# Altium schematic sheet RF_Pi.SchDoc(RF_Pi)
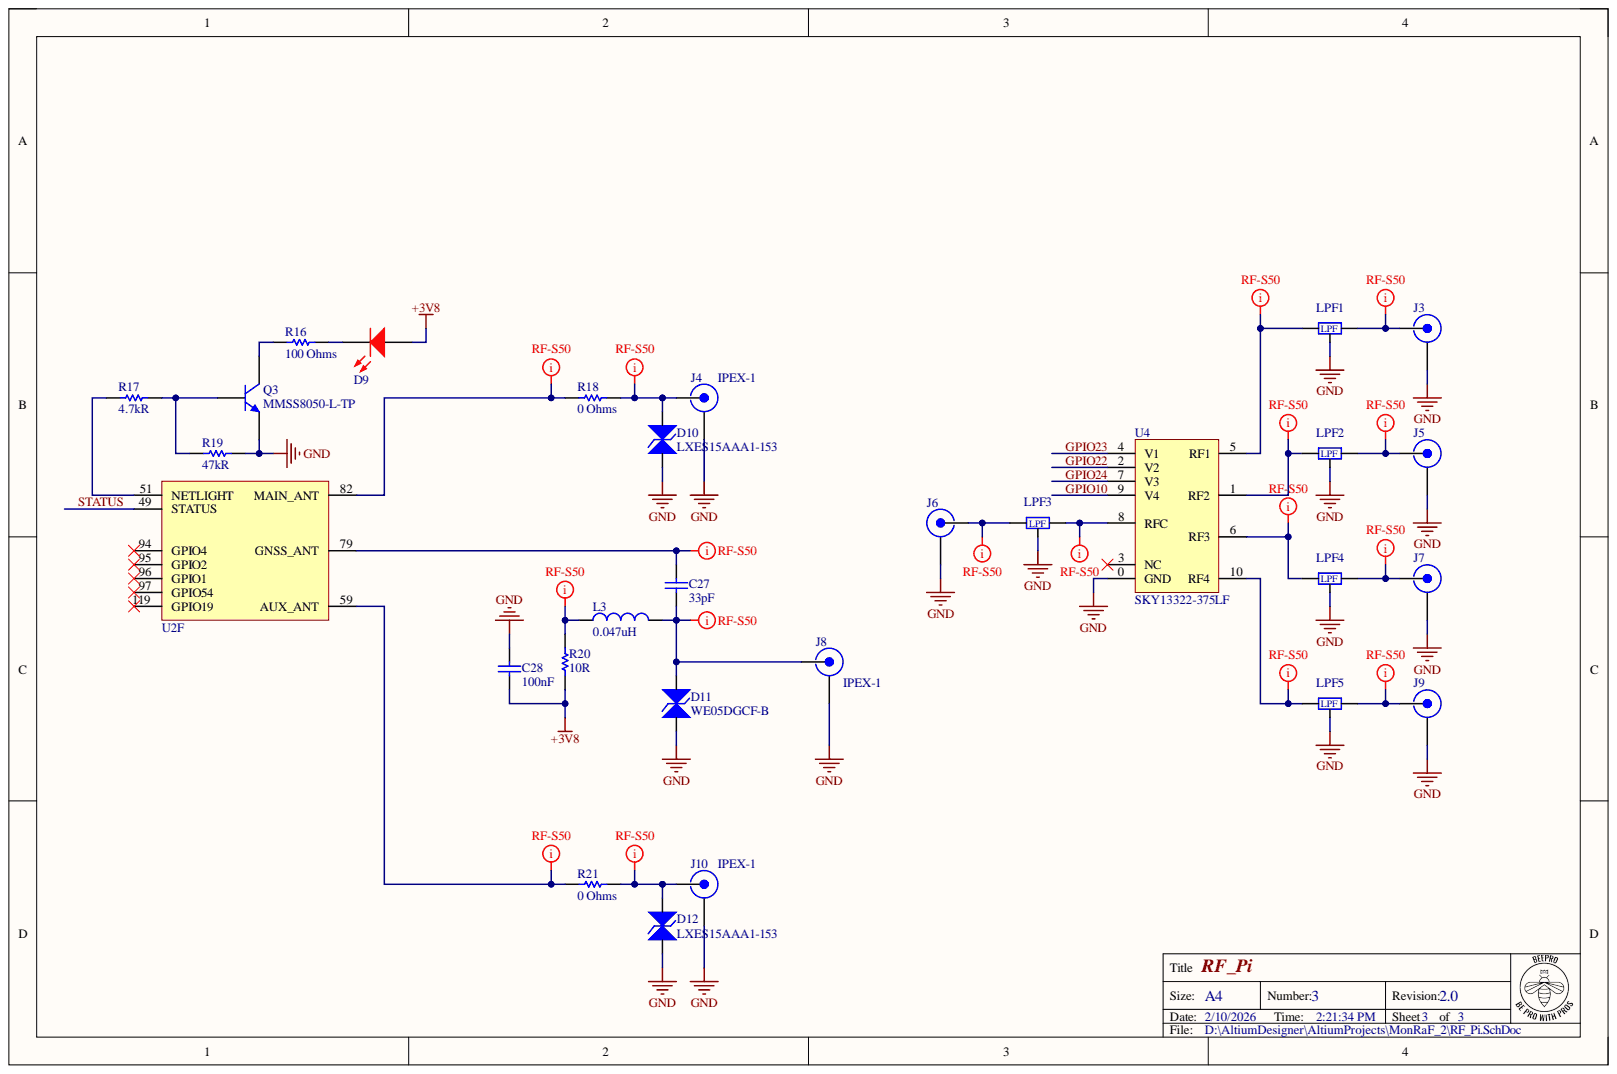
[diagram p0: RF_Pi.SchDoc]

## Parts
C27  value 33pF  [0402N]  (hidden comment: CC0402JRNPO9BN330)
C28  Capacitance: 100nF  [0402N]  (hidden comment: 04026D104KAT2A)
D9  150060RS75000  [0603_LED_RED]  (value not drawn)
D10  LXES15AAA1-153  [DFN90P100X45-2N]
D11  WE05DGCF-B  [DFN1006-2N]
D12  LXES15AAA1-153  [DFN90P100X45-2N]
J3  BWSMA-KE-P001  [SMA]  (value not drawn)
J4  IPEX-1  [IPEX-1]
J5  BWSMA-KE-P001  [SMA]  (value not drawn)
J6  BWSMA-KE-P001  [SMA]  (value not drawn)
J7  BWSMA-KE-P001  [SMA]  (value not drawn)
J8  IPEX-1  [IPEX-1]
J9  BWSMA-KE-P001  [SMA]  (value not drawn)
J10  IPEX-1  [IPEX-1]
L3  Inductance-Nom (L): 0.047uH  [0402N]  (hidden comment: LQG15HS47NJ02D)
LPF1  LFCN-6000+  [LPF3216X09N]  (value not drawn)
LPF2  LFCN-6000+  [LPF3216X09N]  (value not drawn)
LPF3  LFCN-6000+  [LPF3216X09N]  (value not drawn)
LPF4  LFCN-6000+  [LPF3216X09N]  (value not drawn)
LPF5  LFCN-6000+  [LPF3216X09N]  (value not drawn)
Q3  MMSS8050-L-TP  [SOT23-3N]
R16  Resistance: 100 Ohms  [0402N]  (hidden comment: CRCW0402100RJNED)
R17  Resistance: 4.7kR  [0402N]  (hidden comment: RC0402FR-074K7L)
R18  Resistance: 0 Ohms  [0402N]  (hidden comment: CRCW04020000Z0ED)
R19  Resistance: 47kR  [0402N]  (hidden comment: AC0402FR-0747KL)
R20  Resistance: 10R  [0402N]  (hidden comment: ERJ-U02F10R0X)
R21  Resistance: 0 Ohms  [0402N]  (hidden comment: CRCW04020000Z0ED)
U2  SIM7600G-H  [LCC+LGA-119N]  (value not drawn)  (part F)
U4  SKY13322-375LF  [MLPD-11N]

## Nets
+3V8: C28.2 D9.2 R20.2
GND: C28.1 D10.1 D11.1 D12.1 J3.2 J4.2 J5.2 J6.2 J7.2 J8.2 J9.2 J10.2 LPF1.2 LPF2.2 LPF3.2 LPF4.2 LPF5.2 Q3.2 R19.2 U4.0(GND)
GPIO10: U4.9(V4)
GPIO22: U4.2(V2)
GPIO23: U4.4(V1)
GPIO24: U4.7(V3)
STATUS: U2.49(STATUS)
NetC27_1: C27.1 D11.2 J8.1 L3.2
NetC27_2: C27.2 U2.79(GNSS_ANT)
NetD9_1: D9.1 R16.2
NetD10_2: D10.2 J4.1 R18.2
NetD12_2: D12.2 J10.1 R21.2
NetJ3_1: J3.1 LPF1.1
NetJ5_1: J5.1 LPF2.1
NetJ6_1: J6.1 LPF3.3
NetJ7_1: J7.1 LPF4.1
NetJ9_1: J9.1 LPF5.1
NetL3_1: L3.1 R20.1
NetLPF1_3: LPF1.3 U4.5(RF1)
NetLPF2_3: LPF2.3 U4.1(RF2)
NetLPF3_1: LPF3.1 U4.8(RFC)
NetLPF4_3: LPF4.3 U4.6(RF3)
NetLPF5_3: LPF5.3 U4.10(RF4)
NetQ3_1: Q3.1 R17.2 R19.1
NetQ3_3: Q3.3 R16.1
NetR17_1: R17.1 U2.51(NETLIGHT)
NetR18_1: R18.1 U2.82(MAIN_ANT)
NetR21_1: R21.1 U2.59(AUX_ANT)

Not connected: U2 94(GPIO4) 95(GPIO2) 96(GPIO1) 97(GPIO54) 119(GPIO19); U4 3(NC)

## Library data of the parts
C27 = CC0402JRNPO9BN330: Ceramic Capacitor, Multilayer, Ceramic, 50V, 5% +Tol, 5% -Tol, C0G, 30ppm/Cel TC, 0.000033uF, Surface Mount, 0402; Manufacturer: Yageo; Multilayer: Yes; Capacitor Type: Ceramic; Case/Package: 0402; Category: Ceramic Capacitors; Component Kind: Standard; ComponentLink1Description: https://datasheet.ciiva.com:443/pdfs/VipMasterIC/IC/YAGO/YAGO-S-A0009251638/YAGO-S-A0009251638-1.pdf?src-supplier=IHS+Markit; ComponentLink1URL: https://datasheet.ciiva.com:443/pdfs/VipMasterIC/IC/YAGO/YAGO-S-A0009251638/YAGO-S-A0009251638-1.pdf?src-supplier=IHS+Markit; ComponentLink2Description: https://datasheet.ciiva.com/143/mlccorderingcode-143995.pdf?src-supplier=Digikey; ComponentLink2URL: https://datasheet.ciiva.com/143/mlccorderingcode-143995.pdf?src-supplier=Digikey; ComponentLink3Description: https://datasheet.ciiva.com/143/mlccorderingcode-143996.pdf?src-supplier=Digikey; ComponentLink3URL: https://datasheet.ciiva.com/143/mlccorderingcode-143996.pdf?src-supplier=Digikey; ComponentLink4Description: https://datasheet.ciiva.com/143/mlccorderingcode-143997.pdf?src-supplier=Digikey; ComponentLink4URL: https://datasheet.ciiva.com/143/mlccorderingcode-143997.pdf?src-supplier=Digikey; Dielectric Material: Ceramic; Height: 0.5mm; JESD-609 Code: e3; Length: 1mm; Library Name: Capacitor_Ceramic.IntLib; Manufacturer Part Number: CC0402JRNPO9BN330; Max Operating Temperature: 125°C; Min Operating Temperature: -55°C; Mounting Technology: Surface Mount; Package Shape: Rectangular; Package Style: SMTMeter; Packaging: Tape and Reel; Pins: 2; Pricing: 1=0.1, 10=0.016, 50=0.016, 100=0.01, 1000=0.008, 10000=0.005 (USD); Rated DC Voltage (URdc): 50V; Reach SVHC Compliant: Yes; RoHS: Yes; RoHS Compliant: Yes; Stock: 175157; Supplier: Mouser; Supplier Part Number: 603-CC402JRNPO9BN330; Temperature Characteristics Code: C0G; Terminal Finish: Nickel; Termination: Wraparound; Tolerance  (fill in as +-): 5%; Tolerance (fill in as +-): 5%; Width: 0.5mm
C28 = 04026D104KAT2A: AVX   04026D104KAT2A   Multilayer Ceramic Capacitor, 0.1 F,  10%, X5R, 6.3 V, 0402 [1005 Metric]; Manufacturer: Kyocera AVX; Voltage: 6.3V; Case Code (Imperial): 0402; Case Code (Metric): 1005; Case/Package: 0402; Component Kind: Standard; ComponentLink1Description: https://datasheet.ciiva.com/11787/0900766b80def1a5-11787108.pdf?src-supplier=RSComponents; ComponentLink1URL: https://datasheet.ciiva.com/11787/0900766b80def1a5-11787108.pdf?src-supplier=RSComponents; ComponentLink2Description: https://datasheet.ciiva.com/34303/2009885-34303800.pdf?src-supplier=Jameco; ComponentLink2URL: https://datasheet.ciiva.com/34303/2009885-34303800.pdf?src-supplier=Jameco; ComponentLink3Description: https://datasheet.ciiva.com/1123/1666675-1123895.pdf?src-supplier=Element14; ComponentLink3URL: https://datasheet.ciiva.com/1123/1666675-1123895.pdf?src-supplier=Element14; ComponentLink4Description: https://datasheet.ciiva.com/7709/1666675-7709559.pdf?src-supplier=Element14; ComponentLink4URL: https://datasheet.ciiva.com/7709/1666675-7709559.pdf?src-supplier=Element14; ComponentLink5Description: https://datasheet.ciiva.com/17488/cx5r-17488599.pdf?src-supplier=Avnet+Europe; ComponentLink5URL: https://datasheet.ciiva.com/17488/cx5r-17488599.pdf?src-supplier=Avnet+Europe; ComponentLink6Description: https://datasheet.ciiva.com/1123/1666675-1123896.pdf?src-supplier=Element14; ComponentLink6URL: https://datasheet.ciiva.com/1123/1666675-1123896.pdf?src-supplier=Element14; ComponentLink7Description: https://datasheet.ciiva.com/11499/cx5r-11499578.pdf?src-supplier=Avnet; ComponentLink7URL: https://datasheet.ciiva.com/11499/cx5r-11499578.pdf?src-supplier=Avnet; ComponentLink8Description: https://datasheet.ciiva.com/210/cx5r-210347.pdf?src-supplier=Digi-Key; ComponentLink8URL: https://datasheet.ciiva.com/210/cx5r-210347.pdf?src-supplier=Digi-Key; ComponentLink9Description: https://datasheet.ciiva.com/14886/cx5r-14886812.pdf?src-supplier=Digi-Key; ComponentLink9URL: https://datasheet.ciiva.com/14886/cx5r-14886812.pdf?src-supplier=Digi-Key; ComponentLink10Description: https://datasheet.ciiva.com/2987/cx5r-2987693.pdf?src-supplier=Avnet; ComponentLink10URL: https://datasheet.ciiva.com/2987/cx5r-2987693.pdf?src-supplier=Avnet; Contact Plating: Tin; Depth: 0.5mm; Dielectric: X5R; Dielectric Material: Ceramic; Height: 0.56mm; Lead Free: Lead Free; Length: 1mm; Library Name: Capacitor_Ceramic.IntLib; Manufacturer Part Number: 04026D104KAT2A; Max Operating Temperature: 85°C; Min Operating Temperature: -55°C; Mount: Surface Mount; Package Quantity: 1; Packaging: Tape and Reel; Pricing 1: 1 = 0.10000, 10 = 0.04100, 50 = 0.04100, 100 = 0.01900, 1000 = 0.01000, 10000 = 0.00700 (USD); Radiation Hardening: No; REACH SVHC: No SVHC; RoHS: Yes; Stock 1: 118561; Supplier 1: Mouser; Supplier Part Number 1: 581-04026D104KAT2A; Termination: SMD/SMT; Thickness: 0.022inch; Tolerance: 10%; Voltage Rating: 6.3V; Voltage Rating (DC): 6.3V; Width: 0.02inch
D9 = 150060RS75000: WURTH ELEKTRONIK - 150060RS75000 - LED, 0603, RED, 250MCD, 625NM; Manufacturer: Wurth Electronics; Forward Current: 30mA; Forward Voltage: 2V; Lens Style: Clear,Rectangular; Test Current: 20mA; Viewing Angle: 140°; Case Code (Imperial): 0603; Case Code (Metric): 1608; Case/Package: 0603; Color: Red; Component Kind: Standard; ComponentLink1Description: https://katalog.we-online.de/led/datasheet/150060RS75000.pdf?src-supplier=Digi-Key; ComponentLink1URL: https://katalog.we-online.de/led/datasheet/150060RS75000.pdf?src-supplier=Digi-Key; ComponentLink2Description: http://www.produktinfo.conrad.com/datenblaetter/750000-774999/759428-da-01-en-CHIPLED_0603_W_KL_ROT_150060RS75000.pdf?src-supplier=Conrad; ComponentLink2URL: http://www.produktinfo.conrad.com/datenblaetter/750000-774999/759428-da-01-en-CHIPLED_0603_W_KL_ROT_150060RS75000.pdf?src-supplier=Conrad; Dominant Wavelength: 625nm; Height: 0.7mm; Length: 1.6mm; Library Name: Diodes.IntLib; Luminous Intensity: 250mcd; Manufacturer Part Number: 150060RS75000; Max Operating Temperature: 85°C; Min Operating Temperature: -40°C; Mount: Surface Mount; Package Quantity: 1; Packaging: Cut Tape; Pricing 1: 1 = 0.14000, 50 = 0.12900, 100 = 0.11000, 500 = 0.09500 (USD); REACH SVHC: Unknown; RoHS: Yes; Stock 1: 248052; Supplier 1: Digi-Key; Supplier Part Number 1: 732-4978-1-ND; Wavelength: 625nm; Width: 0.8mm
D10 D12 = LXES15AAA1-153: 0402 ESD and Surge Protection (TVS/ESD) ROHS; Manufacturer: LEIDITECH; Component Kind: Standard; Library Name: Diodes.IntLib; Manufacturer Part Number: LXES15AAA1-153; Pricing 1: 10=0.0353, 100=0.0289, 300=0.0257, 1000=0.0233, 5000=0.0204, 10000=0.0194 (USD); RoHS: No; Stock 1: 16704; Supplier 1: LCSC; Supplier Part Number 1: C5210978
D11 = WE05DGCF-B: DFN1006-2L ESD and Surge Protection (TVS/ESD) ROHS; Component Kind: Standard; Library Name: Diodes.IntLib
J3 J5-J7 J9 = BWSMA-KE-P001: 1 Inner Hole SMA Board-End Staggered pins 50Ω 5.2mm Plugin Coaxial Connectors (RF) ROHS; Manufacturer: BAT Wireless; Component Kind: Standard; Library Name: Connectors.IntLib; Manufacturer Part Number: BWSMA-KE-P001; Pricing 1: 1=0.3529, 10=0.2804, 30=0.2442, 100=0.2127, 500=0.2048, 1000=0.2001 (USD); RoHS: No; Stock 1: 44190; Supplier 1: LCSC; Supplier Part Number 1: C496550
J4 J8 J10 = IPEX-1: SMD RF Connectors / Coaxial Connectors ROHS; Manufacturer: Ziisor; Category: Uncategorized; Component Kind: Standard; Library Name: Connectors.IntLib; Manufacturer Part Number: IPEX-1; RoHS: No; Supplier 1: LCSC; Supplier Part Number 1: C2987682
L3 = LQG15HS47NJ02D: General Purpose Inductor, 0.047uH, 5%, 1 Element, Air-Core, SMD, 0402; Manufacturer: Murata; Case/Size Code: 0402; Channels: 1; Core Material: Air; DC Resistance (DCR): 720mR; Inductor Application: RF INDUCTOR; Max Current Rating: 300mA; Package Height: 0.5mm; Package Length: 1mm; Quality Factor-Min (at L-nom): 8; Self Resonant Frequency: 1GHz; Shape/Size Description: RECTANGULAR PACKAGE; Shielding: Unshielded; Test Frequency: 100MHz; Category: Fixed Inductors; Component Kind: Standard; ComponentLink1Description: https://datasheet.ciiva.com:443/pdfs/VipMasterIC/IC/MURE/MURE-S-A0004224658/MURE-S-A0004277638-1.pdf?src-supplier=IHS+Markit; ComponentLink1URL: https://datasheet.ciiva.com:443/pdfs/VipMasterIC/IC/MURE/MURE-S-A0004224658/MURE-S-A0004277638-1.pdf?src-supplier=IHS+Markit; ComponentLink2Description: https://datasheet.ciiva.com/11799/0900766b8090d685-11799071.pdf?src-supplier=Rs; ComponentLink2URL: https://datasheet.ciiva.com/11799/0900766b8090d685-11799071.pdf?src-supplier=Rs; ComponentLink3Description: https://datasheet.ciiva.com/1038/l0075s0083lqg15hs-1038339.pdf?src-supplier=Digikey; ComponentLink3URL: https://datasheet.ciiva.com/1038/l0075s0083lqg15hs-1038339.pdf?src-supplier=Digikey; ComponentLink4Description: https://datasheet.ciiva.com/1038/l0075s0083lqg15hs-1038337.pdf?src-supplier=Digikey; ComponentLink4URL: https://datasheet.ciiva.com/1038/l0075s0083lqg15hs-1038337.pdf?src-supplier=Digikey; ComponentLink5Description: https://datasheet.ciiva.com/1038/l0075s0083lqg15hs-1038338.pdf?src-supplier=Digikey; ComponentLink5URL: https://datasheet.ciiva.com/1038/l0075s0083lqg15hs-1038338.pdf?src-supplier=Digikey; ComponentLink6Description: https://datasheet.ciiva.com/4977/o05e-4977919.pdf?src-supplier=Mouser; ComponentLink6URL: https://datasheet.ciiva.com/4977/o05e-4977919.pdf?src-supplier=Mouser; ComponentLink7Description: https://datasheet.ciiva.com/18088/getdatasheetpartid-55809-18088839.pdf?src-supplier=Verical; ComponentLink7URL: https://datasheet.ciiva.com/18088/getdatasheetpartid-55809-18088839.pdf?src-supplier=Verical; Construction: Multilayer Chip; Inductor Type: GENERAL PURPOSE INDUCTOR; JESD-609 Code: e3; Library Name: Inductors.IntLib; Manufacturer Part Number: LQG15HS47NJ02D; Max Operating Temperature: 125°C; Min Operating Temperature: -55°C; Package Style: SMTMeter; Package Width: 0.5mm; Packaging: Tape and Reel; Pins: 2; Pricing 1: 1=0.1, 10=0.04, 50=0.04, 100=0.031, 1000=0.03, 10000=0.026 (USD); Reach SVHC Compliant: Yes; RoHS: Yes; RoHS Compliant: Yes; Stock 1: 11986; Supplier 1: Mouser; Supplier Part Number 1: 81-LQG15HS47NJ02D; Surface Mount: Yes; Terminal Finish: Nickel; Terminal Placement: Dual Ended; Termination: Wraparound; Tolerance: 5%
LPF1-LPF5 = LFCN-6000+: Low Pass Filter / Ceramic Filters Low Pass 6000MHz 1.2dB 50Ohm SMD 4Pin T/R; Manufacturer: Mini-Circuits; Attenuation Value: 20dB; Cutoff Frequency: 6.8GHz; Insertion Loss (dB): 3dB; Category: Ceramic Filters; Component Kind: Standard; ComponentLink1Description: https://datasheet.octopart.com:443/LFCN-6000%2B-Mini-Circuits-datasheet-180701403.pdf?src-supplier=IHS; ComponentLink1URL: https://datasheet.octopart.com:443/LFCN-6000%2B-Mini-Circuits-datasheet-180701403.pdf?src-supplier=IHS; ComponentLink2Description: https://datasheet.octopart.com:443/LFCN-6000%2B-Mini-Circuits-datasheet-103441202.pdf?src-supplier=Component+Distributors+Inc.; ComponentLink2URL: https://datasheet.octopart.com:443/LFCN-6000%2B-Mini-Circuits-datasheet-103441202.pdf?src-supplier=Component+Distributors+Inc.; Height: 940µm; Length: 3.2mm; Library Name: Inductors.IntLib; Lifecycle Status: Production; Manufacturer Part Number: LFCN-6000+; Max Operating Temperature: 100°C; Min Operating Temperature: -55°C; Pricing: 1=20.0123, 1=21.8764, 1=2.3851, 1=2.7414, 10=18.37, 10=2.0028, 10=16.8046, 10=2.302, 30=14.7855, 30=16.1627, 30=2.0254, 30=1.7621, 100=13.9188, 100=1.7442, 100=1.5175, 100=12.7327, 500=11.8012, 500=1.6166, 500=12.9005, 500=1.4065, 1000=11.3975, 1000=12.4592, 1000=1.5613, 1000=1.3584 (CNY); REACH SVHC: Yes; RoHS: Compliant; Stock: 11; Supplier: LCSC; Supplier Part Number: C879870; Width: 1.6mm
Q3 = MMSS8050-L-TP: TRANS NPN 25V 1.5A SOT-23; Manufacturer: MCC; Collector Base Voltage (VCBO): 40V; Collector Emitter Saturation Voltage: 500mV; Element Configuration: Single; Emitter Base Voltage (VEBO): 5V; Gain Bandwidth Product: 100MHz; hFE Min: 40; Max Power Dissipation: 625mW; Case/Package: TO-236-3; Collector Emitter Breakdown Voltage: 25V; Collector Emitter Voltage (VCEO): 500mV; Component Kind: Standard; ComponentLink1Description: https://datasheet.ciiva.com/6362/mmss8050sot-23-6362361.pdf; ComponentLink1URL: https://datasheet.ciiva.com/6362/mmss8050sot-23-6362361.pdf; ComponentLink2Description: https://datasheet.ciiva.com/16285/mmss8050sot-23-276387-16285577.pdf; ComponentLink2URL: https://datasheet.ciiva.com/16285/mmss8050sot-23-276387-16285577.pdf; ComponentLink3Description: https://datasheet.ciiva.com/6362/mmss8050sot-23-6362362.pdf; ComponentLink3URL: https://datasheet.ciiva.com/6362/mmss8050sot-23-6362362.pdf; ComponentLink4Description: https://datasheet.ciiva.com/6362/mmss8050sot-23-6362363.pdf; ComponentLink4URL: https://datasheet.ciiva.com/6362/mmss8050sot-23-6362363.pdf; Lead Free: Lead Free; Manufacturer Part Number: MMSS8050-L-TP; Max Breakdown Voltage: 25V; Max Collector Current: 1.5A; Max Operating Temperature: 150°C; Min Operating Temperature: -55°C; Mount: Surface Mount; Package Quantity: 3000; Packaging: Tape and Reel; Polarity: NPN; Pricing 1: 1=0.19, 10=0.165, 50=0.165, 100=0.058, 1000=0.04, 10000=0.026 (USD); Stock 1: 30112; Supplier 1: Mouser; Supplier Part Number 1: 833-MMSS8050-L-TP; Transition Frequency: 100MHz; Library Name: Micro Comercial Components.IntLib
R16 = CRCW0402100RJNED: Thick Film Resistors - SMD 1/16watt 100ohms 5%; Brand: Vishay; Power Rating: 63 mW; Qualification: Commercial; Temperature Coefficient: 200 PPM / K; Voltage Rating: 50 V; Case Code - in: 0402; Case Code - mm: 1005; Category: Thick Film Resistors - SMD; Component Kind: Standard; ComponentLink1Description: http://www.mouser.com/ds/2/427/dcrcwe3-109170.pdf; ComponentLink1URL: http://www.mouser.com/ds/2/427/dcrcwe3-109170.pdf; Features: Metal glaze on high quality ceramic; Height: 0.35 mm; Length: 1 mm; Library Name: Resistor_0402.IntLib; Manufacturer: Vishay; Manufacturer Part Number 1: CRCW0402100RJNED; Minimum Order Quantity: 1; Operating Temperature Range: - 55 C to + 155 C; Package / Case: 0402 (1005 metric); Packaging: Reel; Pricing 1: 1=0.100, 10=0.040, 100=0.023, 1000=0.016, 10000=0.004, 50000=0.003 (USD); Product: Thick Film Resistors SMD; Reeling: True; RoHS: RoHS Compliant By Exemption; RoHS - Mouser: E; Series: CRCW e3; Standard Pack Qty: 10000; Stock 1: 46909; Supplier 1: Mouser; Supplier Part Number 1: 71-CRCW0402J-100-E3; Termination Style: SMD/SMT; Tolerance: 5 %; Width: 0.5 mm
R17 = RC0402FR-074K7L: YAGEO (PHYCOMP)         RC0402FR-074K7L             SMD Chip Resistor, Thick Film, 4.7 kohm, 50 V, 0402 [1005 Metric], 63 mW,  1%, RC Series; Power Rating: 62.5mW; Temperature Coefficient: 100ppm/°C; Voltage Rating: 50V; Case Code (Imperial): 0402; Case Code (Metric): 1005; Case/Package: 0402; Component Kind: Standard; ComponentLink1Description: https://datasheet.ciiva.com/11819/0900766b80c66297-11819507.pdf; ComponentLink1URL: https://datasheet.ciiva.com/11819/0900766b80c66297-11819507.pdf; ComponentLink2Description: https://datasheet.ciiva.com/7850/1018594-7850022.pdf; ComponentLink2URL: https://datasheet.ciiva.com/7850/1018594-7850022.pdf; ComponentLink3Description: https://datasheet.ciiva.com/7850/1018594-7850023.pdf; ComponentLink3URL: https://datasheet.ciiva.com/7850/1018594-7850023.pdf; ComponentLink4Description: https://datasheet.ciiva.com/15215/getdatasheetpartid-328119-15215035.pdf; ComponentLink4URL: https://datasheet.ciiva.com/15215/getdatasheetpartid-328119-15215035.pdf; ComponentLink5Description: https://datasheet.ciiva.com/22661/1686128-22661342.pdf; ComponentLink5URL: https://datasheet.ciiva.com/22661/1686128-22661342.pdf; Composition: Thick Film; Depth: 0.5mm; Height: 0.32mm; Lead Free: Lead Free; Length: 1mm; Library Name: Resistor_0402.IntLib; Manufacturer: Yageo; Manufacturer Part Number: RC0402FR-074K7L; Max Operating Temperature: 155°C; Military Standard: Not; Min Operating Temperature: -55°C; Number of Pins: 2; Packaging: Tape and Reel; Pricing 1: 1=0.1, 10=0.009, 50=0.009, 100=0.003, 1000=0.002, 10000=0.002 (USD); REACH SVHC: No SVHC; Stock 1: 1080519; Supplier 1: Mouser; Supplier Part Number 1: 603-RC0402FR-074K7L; Termination: SMD/SMT; Tolerance: 1%; Voltage Rating (DC): 50V; Width: 0.5mm
R18 R21 = CRCW04020000Z0ED: Thick Film Resistors - SMD 1/16watt 0ohms; Brand: Vishay; Power Rating: 63 mW; Qualification: Commercial; Case Code - in: 0402; Case Code - mm: 1005; Category: Thick Film Resistors - SMD; Component Kind: Standard; ComponentLink1Description: http://www.mouser.com/ds/2/427/dcrcwe3-109170.pdf; ComponentLink1URL: http://www.mouser.com/ds/2/427/dcrcwe3-109170.pdf; Height: 0.35 mm; Length: 1 mm; Library Name: Resistor_0402.IntLib; Manufacturer: Vishay; Manufacturer Part Number 1: CRCW04020000Z0ED; Minimum Order Quantity: 1; Operating Temperature Range: - 55 C to + 155 C; Package / Case: 0402 (1005 metric); Packaging: Reel; Pricing 1: 1=0.100, 10=0.040, 100=0.023, 1000=0.016, 10000=0.004, 50000=0.003 (USD); Product: Thick Film Resistors SMD; Reeling: True; RoHS: RoHS Compliant By Exemption; RoHS - Mouser: E; Series: CRCW e3; Standard Pack Qty: 10000; Stock 1: 690804; Supplier 1: Mouser; Supplier Part Number 1: 71-CRCW0402-0-E3; Temperature Coefficient: -; Termination Style: SMD/SMT; Tolerance: -; Type: Zero Ohm Jumper; Voltage Rating: -; Width: 0.5 mm
R19 = AC0402FR-0747KL: Thick Film Resistors - SMD 1/16W 47K ohm 1% AEC-Q200; Manufacturer: Yageo; Max Power Dissipation: 63mW; Power Rating: 62.5mW; Temperature Coefficient: 100ppm/°C; Voltage Rating: 50V; Case Code (Imperial): 0402; Case Code (Metric): 1005; Case/Package: 0402; Component Kind: Standard; Composition: Thick Film; Features: Automotive AEC-Q200,Moisture Resistant; Height: 0.015inch; Lead Free: Lead Free; Length: 0.039inch; Library Name: Resistor_0402.IntLib; Manufacturer Part Number: AC0402FR-0747KL; Max Operating Temperature: 155°C; Min Operating Temperature: -55°C; Number of Terminations: 2; Packaging: Tape and Reel; Pricing 1: 1=0.1, 10=0.017, 50=0.017, 100=0.006, 1000=0.005, 10000=0.003 (USD); Stock 1: 5185; Supplier 1: Mouser; Supplier Part Number 1: 603-AC0402FR-0747KL; Termination: SMD/SMT; Tolerance: 1%; Weight: 2.3E-05oz; Width: 0.5mm
R20 = ERJ-U02F10R0X: Res Thick Film 0402 10 Ohm 1% 0.1W(1/10W) ±200ppm/C Sulfur Resistant Pad SMD Automotive T/R; Manufacturer: Panasonic; Category: Chip SMD Resistors; Component Kind: Standard; ComponentLink1Description: https://datasheet.ciiva.com/22802/1783557-22802477.pdf?src-supplier=Farnell; ComponentLink1URL: https://datasheet.ciiva.com/22802/1783557-22802477.pdf?src-supplier=Farnell; ComponentLink2Description: https://datasheet.ciiva.com/22739/1783557-22739333.pdf?src-supplier=Element14; ComponentLink2URL: https://datasheet.ciiva.com/22739/1783557-22739333.pdf?src-supplier=Element14; ComponentLink3Description: https://datasheet.ciiva.com/22730/1783557-22730925.pdf?src-supplier=Element14; ComponentLink3URL: https://datasheet.ciiva.com/22730/1783557-22730925.pdf?src-supplier=Element14; Library Name: Resistor_0402.IntLib; Manufacturer Part Number: ERJ-U02F10R0X; Pricing 1: 1=0.1, 10=0.049, 50=0.049, 100=0.022, 1000=0.016, 10000=0.01 (USD); RoHS: Yes; Stock 1: 341179; Supplier 1: Mouser; Supplier Part Number 1: 667-ERJ-U02F10R0X
U2 = SIM7600G-H: Cellular Module, GSM, LTE-FDD, LTE-TDD, WCDMA, 3.4 V to 4.2 V, 30 mm x 30 mm x 2.9 mm; Manufacturer: Simcom; Manufacturer Part Number: SIM7600G-H R2; Category: RF Transceiver Modules and Modems; Component Kind: Standard; Length: 30m; Library Name: SIMCom.IntLib; Pricing: 1=51.98, 10=45.558, 25=43.3328, 100=40.2842 (USD); Stock: 421; Supplier: DigiKey; Supplier Part Number: 3781-SIM7600G-HR2-ND
U4 = SKY13322-375LF: Diversity Switch, 20MHz Min, 6000MHz Max, 1.25dB Insertion Loss-Max; Manufacturer: Skyworks Solutions; Clock Frequency: 6GHz; IIP3: 51dBm; Impedance: 50Ω; P1dB: 30dBm; Throw Configuration: SP4T; Turn-Off Delay Time: 60ns; Case/Package: QFN; Category: RF Switches; Component Kind: Standard; ComponentLink1Description: https://datasheet.octopart.com:443/SKY13322-375LF-Skyworks-Solutions-datasheet-11047633.pdf?src-supplier=IHS; ComponentLink1URL: https://datasheet.octopart.com:443/SKY13322-375LF-Skyworks-Solutions-datasheet-11047633.pdf?src-supplier=IHS; ComponentLink2Description: https://datasheet.octopart.com:443/SKY13322-375LF-Skyworks-Solutions-datasheet-8616232.pdf?src-supplier=Component+Distributors+Inc.; ComponentLink2URL: https://datasheet.octopart.com:443/SKY13322-375LF-Skyworks-Solutions-datasheet-8616232.pdf?src-supplier=Component+Distributors+Inc.; Frequency: 20MHz; Insertion Loss (dB): 1.25dB; Library Name: Skyworks.IntLib; Lifecycle Status: Production; Manufacturer Part Number: SKY13322-375LF; Max Operating Temperature: 85°C; Min Operating Temperature: -40°C; Pins: 10; Pricing: 1=17.9658, 1=2.1382, 1=19.6125, 1=2.4577, 10=17.6179, 10=2.0968, 10=19.2326, 10=2.4101, 30=17.3825, 30=2.0688, 30=18.9757, 30=2.3779, 100=17.1471, 100=2.0408, 100=18.7187, 100=2.3457 (CNY); Radiation Hardening: No; REACH SVHC: Yes; RoHS: Compliant; Stock: 21; Supplier: LCSC; Supplier Part Number: C151465; Turn-On Delay Time: 60ns
